# Altium schematic sheet Documento_final.SchDoc
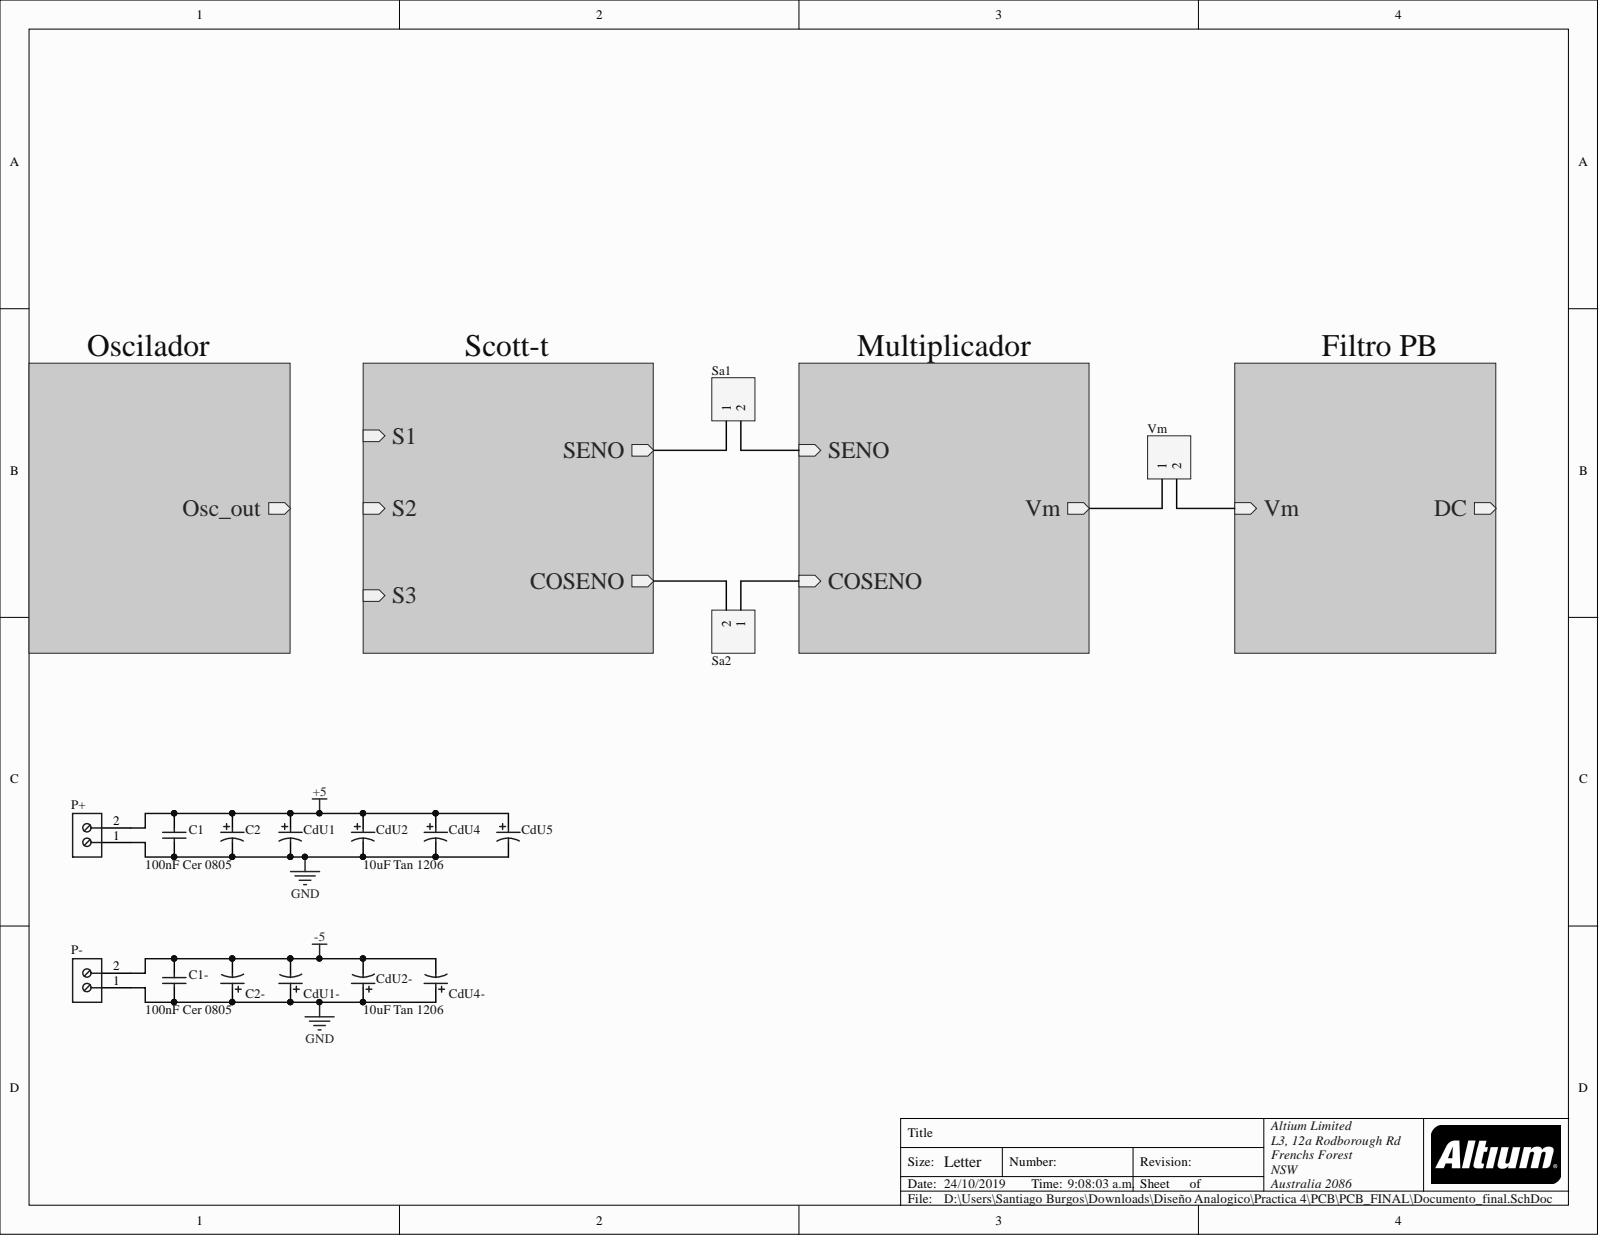
[diagram p0: Documento_final.SchDoc]

## Parts
C1  100nF Cer 0805  Capacitance: 100nF  [CAPC2013X135X45ML10T25]
C1-  100nF Cer 0805  Capacitance: 100nF  [CAPC2013X135X45ML10T25]
C2  10ud Tan 1206  [AVX-1206-2-H1.8_M]  (value not drawn)
C2-  10ud Tan 1206  [AVX-1206-2-H1.8_M]  (value not drawn)
CdU1  10ud Tan 1206  [AVX-1206-2-H1.8_M]  (value not drawn)
CdU1-  10ud Tan 1206  [AVX-1206-2-H1.8_M]  (value not drawn)
CdU2  10uF Tan 1206  [AVX-1206-2-H1.8_M]
CdU2-  10uF Tan 1206  [AVX-1206-2-H1.8_M]
CdU4  Package Reference: 1206  [AVX-1206-2-H1.8_M]  (hidden comment: 10ud Tan 1206)
CdU4-  Package Reference: 1206  [AVX-1206-2-H1.8_M]  (hidden comment: 10ud Tan 1206)
CdU5  10ud Tan 1206  [AVX-1206-2-H1.8_M]  (value not drawn)
P+  282836-2  [TECO-282836-2_V]  (value not drawn)
P-  282836-2  [TECO-282836-2_V]  (value not drawn)
Sa1  Header 2  [HDR1X2]  (value not drawn)
Sa2  Header 2  [HDR1X2]  (value not drawn)
Vm  Header 2  [HDR1X2]  (value not drawn)

## Nets
+5: C1.2 C2.1 CdU1.1 CdU2.1 CdU4.1 CdU5.1 P+.2
-5: C1-.2 C2-.2 CdU1-.2 CdU2-.2 CdU4-.2 P-.2
GND: C1.1 C1-.1 C2.2 C2-.1 CdU1.2 CdU1-.1 CdU2.2 CdU2-.1 CdU4.2 CdU4-.1 CdU5.2 P+.1 P-.1
NetSa1_1: Sa1.1
NetSa1_2: Sa1.2
NetSa2_1: Sa2.1
NetSa2_2: Sa2.2
NetVm_1: Vm.1
NetVm_2: Vm.2
DC:
Osc_out:
S1:
S2:
S3:

## Library data of the parts
C1 C1- = CMP-2100-03644-1: Chip Capacitor, 0.1 uF, +/- 10%, 50 V, -55 to 125 degC, 0805 (2012 Metric), RoHS, Tape and Reel; Manufacturer: Murata; Dielectric: X7R; Dielectric Material: Ceramic; Insulation Resistance: 10GR; Voltage: 50V; Case/Package: 0805; Case Code (Imperial): 0805; Case Code (Metric): 2012; Component Kind: Standard; Datasheet URL: http://psearch.en.murata.com/capacitor/product/GRM21BR71H104KA01%23.pdf; Datasheet Version: 42675.0; Depth: 1.25mm; Height: 1.25mm; Lead Free: LeadFree; Length: 2mm; Library Name: Altium Content Vault; Manufacturer URL: http://www.murata.com/; Material: Ceramic; Max Operating Temperature: 125degC; Min Operating Temperature: -55degC; Mount: SurfaceMount; Number of Pins: 2; Package Description: 2-Pin Surface Mount Device, Body 2 x 1.25 mm; Package Quantity: 1; Package Reference: 0805; Packaging: TapeandReel; Radiation Hardening: No; REACH SVHC: Unknown; RoHSCompliant: true; Termination: SMD/SMT; Thickness: 0.053inch; Tolerance: 10%; Voltage Rating: 50V; Voltage Rating (DC): 50V; Width: 0.049inch
C2 C2- = CMP-2000-07217-1: TAJ Series Standard Tantalum, 10 uF, +/- 10%, -55 to 125 degC, 2-Pin SMD (1206), RoHS, Tape and Reel; Manufacturer: AVX; Manufacturer Size Code: A; PCN Part Number: TAJSeriesSuffix08/Dec/2008; Capacitance: 10µF; Catalog Drawings: TAJSeriesSideTAJSeries; EDA / CAD Models: DownloadfromAcceleratedDesigns; ESR (Equivalent Series Resistance): 3Ohm; Other Names: 478-1654-6; Product Training Modules: ComponentSolutionsforSmartMeterApplications; Type: Molded; Voltage - Rated: 10V; Category: Capacitors; Component Kind: Standard; Datasheet URL: https://datasheet.ciiva.com/26341/559409-da-01-en-tacc-a-3-2-mm-x-1-6-mm-x-1-6-mm-10-uf-26341749.pdf; Datasheet Version: 04/2013; Family: TantalumCapacitors; Features: GeneralPurpose; Height - Seated (Max): 0.071"(1.80mm); Lead Spacing: -; Library Name: Altium Content Vault; Lifetime @ Temp.: -; Manufacturer URL: http://www.avx.com/; Mounting Technology: SurfaceMount; Mounting Type: SurfaceMount; Online Catalog: TAJSeries; Operating Temperature: -55°C~125°C; Package / Case: 1206(3216Metric); Package Description: 2-Pin Chip Capacitor, Body 3.2 x 1.65 mm; Package Reference: 1206; Packaging: Digi-Reel®; Series: TAJ; Size / Dimension: 0.126"Lx0.063"W(3.20mmx1.60mm); Standard Package: 1; Tolerance: ±10%; Voltage Rating DC: 10V
CdU1 CdU1- CdU2 CdU2- CdU4 CdU4- CdU5 = CMP-2000-07217-1: TAJ Series Standard Tantalum, 10 uF, +/- 10%, -55 to 125 degC, 2-Pin SMD (1206), RoHS, Tape and Reel; Manufacturer: AVX; Manufacturer Size Code: A; PCN Part Number: TAJSeriesSuffix08/Dec/2008; Capacitance: 10µF; Catalog Drawings: TAJSeriesSideTAJSeries; EDA / CAD Models: DownloadfromAcceleratedDesigns; ESR (Equivalent Series Resistance): 3Ohm; Other Names: 478-1654-6; Product Training Modules: ComponentSolutionsforSmartMeterApplications; Voltage - Rated: 10V; Category: Capacitors; Component Kind: Standard; Datasheet URL: https://datasheet.ciiva.com/26341/559409-da-01-en-tacc-a-3-2-mm-x-1-6-mm-x-1-6-mm-10-uf-26341749.pdf; Datasheet Version: 04/2013; Family: TantalumCapacitors; Features: GeneralPurpose; Height - Seated (Max): 0.071"(1.80mm); Lead Spacing: -; Library Name: Altium Content Vault; Lifetime @ Temp.: -; Manufacturer URL: http://www.avx.com/; Mounting Technology: SurfaceMount; Mounting Type: SurfaceMount; Online Catalog: TAJSeries; Operating Temperature: -55°C~125°C; Package / Case: 1206(3216Metric); Package Description: 2-Pin Chip Capacitor, Body 3.2 x 1.65 mm; Packaging: Digi-Reel®; Series: TAJ; Size / Dimension: 0.126"Lx0.063"W(3.20mmx1.60mm); Standard Package: 1; Tolerance: ±10%; Type: Molded; Voltage Rating DC: 10V
  CdU1 CdU1- CdU2 CdU2- CdU5: Package Reference: 1206
P+ P- = CMP-1684-00017-1: Female Header, Pitch 5 mm, 1 x 2 Position, Height 10 mm, Tail Length 3.5 mm, Rohs, Bulk; Manufacturer: TE Connectivity; 3D Model: 282836-2.pdf; Clamp Material - Plating: Brass-NickelPlated; Current: 13.5A; Dynamic Catalog: BuchananSeries; Featured Product: EurostyleTerminalBlockConnectors; Mating Orientation: HorizontalwithBoard; Number of Levels: 1; Other Names: 5ULV-02B  A98076; Pitch: 0.197"(5.00mm); Positions Per Level: 2; Product Training Modules: Wire-to-BoardEurostyleTerminalBlocks; Screw Thread: M3; Torque - Screw: 0.68Nm(6Lb-In); Voltage: 300V; Wire Gauge: 16-30AWG; Wire Termination: Screw-RisingCageClamp; Category: Connectors,Interconnects; Color: Green; Component Kind: Standard; Contact Material - Plating: Brass-TinPlated; Datasheet URL: http://www.te.com.cn/commerce/DocumentDelivery/DDEController?Action=showdoc&DocId=Catalog+Section%7F1308389_EUROSTYLE_TERMINAL_BLOCKS%7F0607%7Fpdf%7FEnglish%7FENG_CS_1308389_EUROSTYLE_TERMINAL_BLOCKS_0607.pdf%7F282836-2; Family: TerminalBlocks-WiretoBoard; Features: Interlocking(Side); Housing Material: Polyamide(PA66),Nylon6/6; Library Name: Altium Content Vault; Manufacturer URL: http://www.te.com; Mounting Type: ThroughHole; Package Description: Female Header, Pitch 5 mm, 1 x 2 Position, Height 10 mm, Tail Length 3.5 mm; Package Reference: THD; Packaging: Bulk; RoHS Information: 282836-2StatementofCompliance; Screw Material - Plating: Brass-NickelPlated; Series: Buchanan; Standard Package: 300
Sa1 Sa2 Vm = Header 2: Header, 2-Pin; Component Kind: Standard; LatestRevisionDate: 17-Jul-2002; LatestRevisionNote: Re-released for DXP Platform.; Library Name: Miscellaneous Connectors.IntLib; Signal Integrity: Connector; Publisher: Altium Limited
